# Altium schematic sheet [05] - Probe Line IO Expanders.SchDoc("[05] - Probe Line IO Expanders")
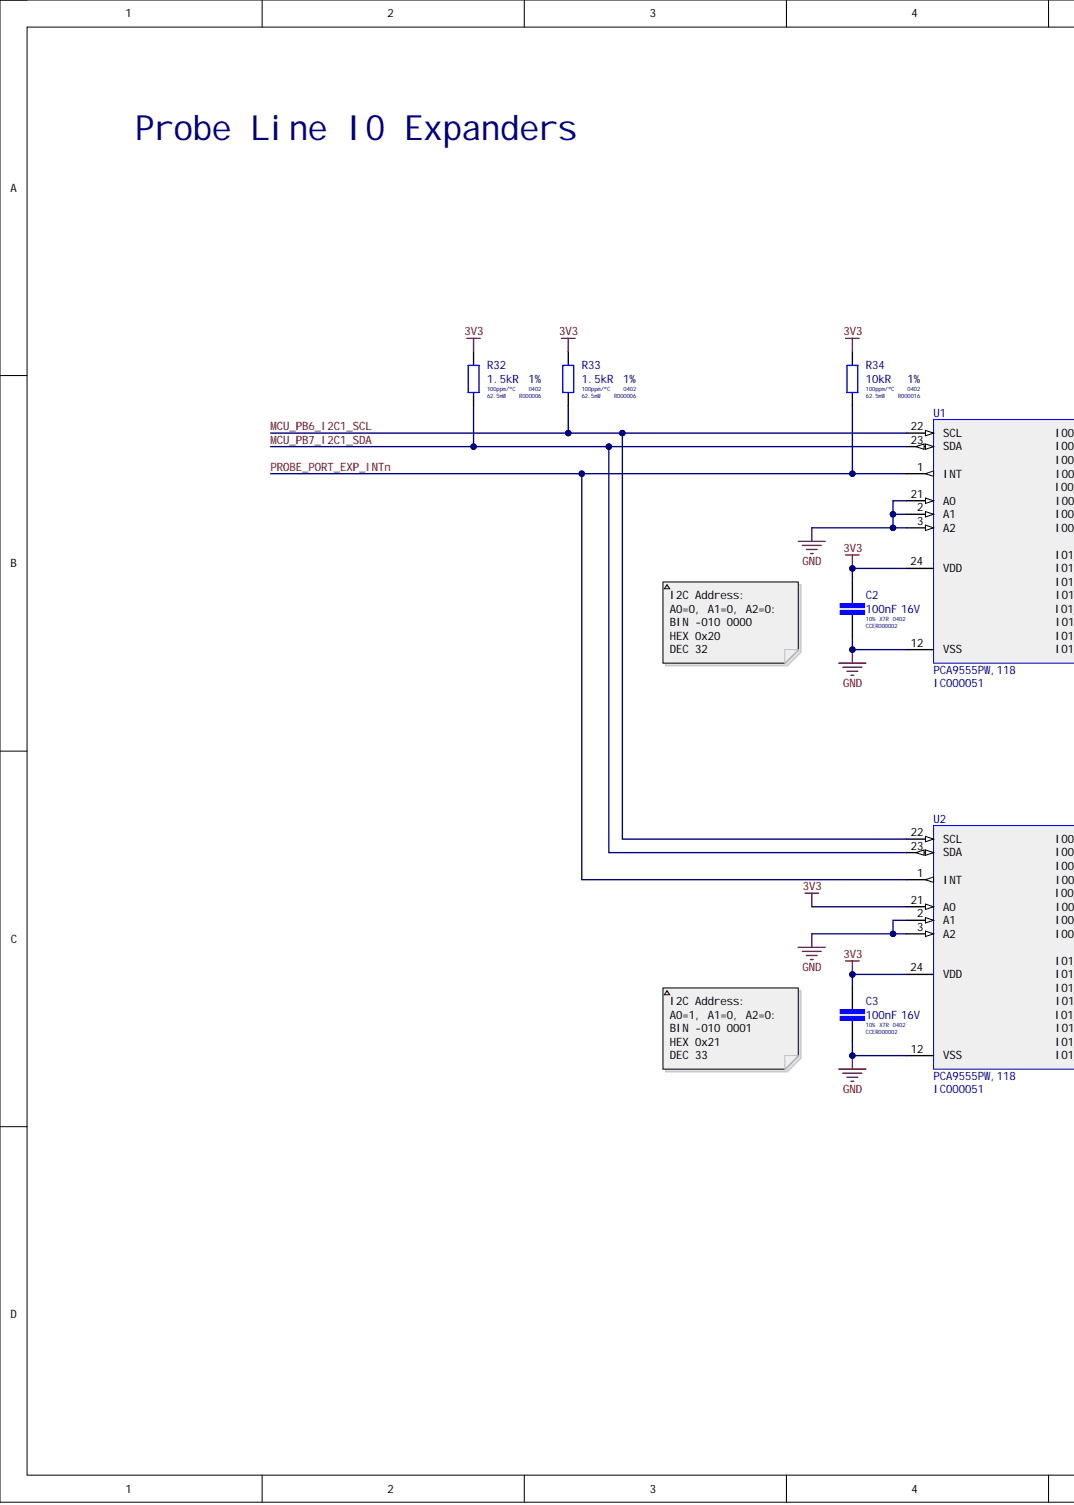
[diagram p0: [05] - Probe Line IO Expanders.SchDoc, left]
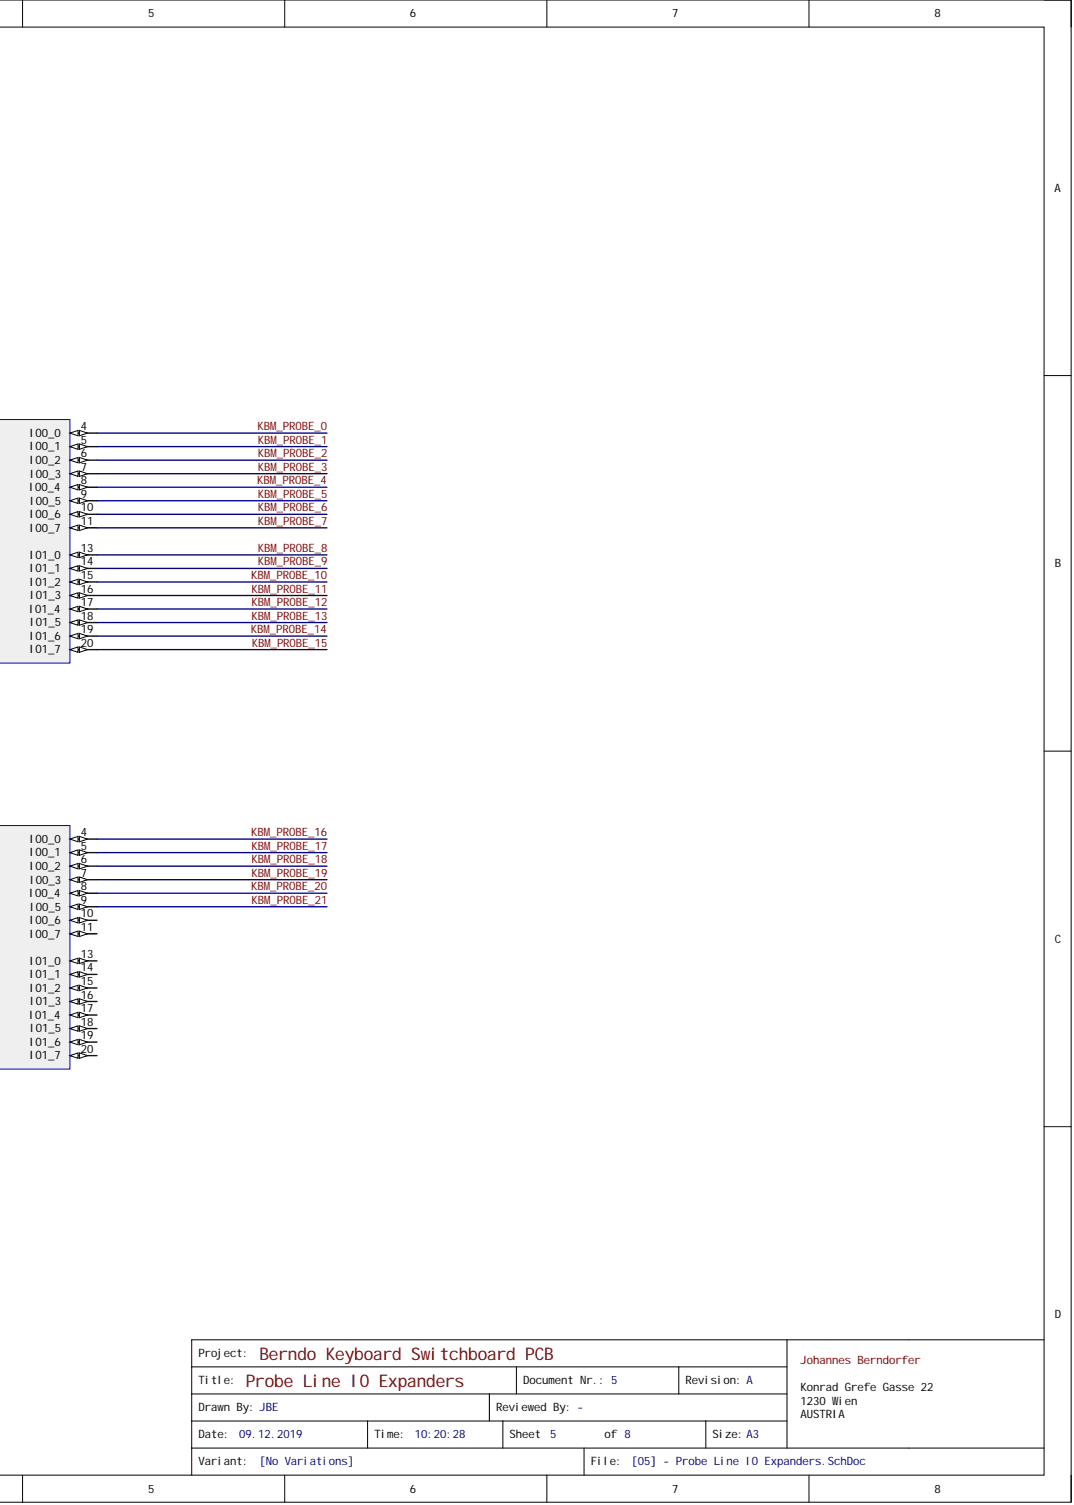
[diagram p1: [05] - Probe Line IO Expanders.SchDoc, right]

## Parts
C2  100nF 16V  Capacitance: 100nF  Dielectric: X7R  Internal Part Number: CCER000002  Tolerance: 10%  [CAP-SMD-0402-0.55MM-IPC-MED]
C3  100nF 16V  Capacitance: 100nF  Dielectric: X7R  Internal Part Number: CCER000002  Tolerance: 10%  [CAP-SMD-0402-0.55MM-IPC-MED]
R32  1.5kR 1%  Max Power Dissipation: 62.5mW  Resistance: 1.5kR  Temperature Coefficient: 100ppm/°C  [RES-SMD-0402-0.4MM-IPC-MED]
R33  1.5kR 1%  Case Code (Imperial): 0402  Internal Part Number: R000006  Max Power Dissipation: 62.5mW  Resistance: 1.5kR  Temperature Coefficient: 100ppm/°C  Tolerance: 1%  [RES-SMD-0402-0.4MM-IPC-MED]
R34  Power Rating: 62.5mW  Resistance: 10kR  Temperature Coefficient: 100ppm/°C  [RES-SMD-0402-0.4MM-IPC-MED]  (hidden comment: 10kR 1%)
U1  PCA9555PW,118  Internal Part Number: IC000051  [TSSOP-24-H1.1MM-P0.65MM-NXP]
U2  PCA9555PW,118  Internal Part Number: IC000051  [TSSOP-24-H1.1MM-P0.65MM-NXP]

## Nets
3V3: C2.1 C3.1 R32.1 R33.1 R34.1 U1.24(VDD) U2.21(A0) U2.24(VDD)
GND: C2.2 C3.2 U1.2(A1) U1.3(A2) U1.12(VSS) U1.21(A0) U2.2(A1) U2.3(A2) U2.12(VSS)
KBM_PROBE_0: U1.4(IO0_0)
KBM_PROBE_1: U1.5(IO0_1)
KBM_PROBE_2: U1.6(IO0_2)
KBM_PROBE_3: U1.7(IO0_3)
KBM_PROBE_4: U1.8(IO0_4)
KBM_PROBE_5: U1.9(IO0_5)
KBM_PROBE_6: U1.10(IO0_6)
KBM_PROBE_7: U1.11(IO0_7)
KBM_PROBE_8: U1.13(IO1_0)
KBM_PROBE_9: U1.14(IO1_1)
KBM_PROBE_10: U1.15(IO1_2)
KBM_PROBE_11: U1.16(IO1_3)
KBM_PROBE_12: U1.17(IO1_4)
KBM_PROBE_13: U1.18(IO1_5)
KBM_PROBE_14: U1.19(IO1_6)
KBM_PROBE_15: U1.20(IO1_7)
KBM_PROBE_16: U2.4(IO0_0)
KBM_PROBE_17: U2.5(IO0_1)
KBM_PROBE_18: U2.6(IO0_2)
KBM_PROBE_19: U2.7(IO0_3)
KBM_PROBE_20: U2.8(IO0_4)
KBM_PROBE_21: U2.9(IO0_5)
MCU_PB6_I2C1_SCL: R33.2 U1.22(SCL) U2.22(SCL)
MCU_PB7_I2C1_SDA: R32.2 U1.23(SDA) U2.23(SDA)
PROBE_PORT_EXP_INTn: R34.2 U1.1(INT) U2.1(INT)

Not connected: U2 10(IO0_6) 11(IO0_7) 13(IO1_0) 14(IO1_1) 15(IO1_2) 16(IO1_3) 17(IO1_4) 18(IO1_5) 19(IO1_6) 20(IO1_7)

## Library data of the parts
C2 C3 = CL05B104KO5NNNC: CAP CER 100N 16V X7R 10% SMD 0402 125C SAMSUNG; Material: Ceramic; Case Code (Imperial): 0402; Case Code (Metric): 1005; Case/Package: 0402; Component Kind: Standard; ComponentLink1Description: https://datasheet.ciiva.com/26920/getdatasheetpartid-359683-26920169.pdf?src-supplier=Verical; ComponentLink1URL: https://datasheet.ciiva.com/26920/getdatasheetpartid-359683-26920169.pdf?src-supplier=Verical; ComponentLink2Description: https://datasheet.ciiva.com/29198/458718-da-01-en-vs-kondensator-0402-29198423.pdf?src-supplier=Conrad; ComponentLink2URL: https://datasheet.ciiva.com/29198/458718-da-01-en-vs-kondensator-0402-29198423.pdf?src-supplier=Conrad; ComponentLink3Description: https://datasheet.ciiva.com/16208/cl05b104kq5nnnc-16208343.pdf?src-supplier=Future+Electronics; ComponentLink3URL: https://datasheet.ciiva.com/16208/cl05b104kq5nnnc-16208343.pdf?src-supplier=Future+Electronics; ComponentLink4Description: https://datasheet.ciiva.com/26309/mlcc-catalogdownload2013-eng-26309003.pdf?src-supplier=Distrelec; ComponentLink4URL: https://datasheet.ciiva.com/26309/mlcc-catalogdownload2013-eng-26309003.pdf?src-supplier=Distrelec; Contact Plating: Tin; Depth: 0.5mm; Height: 0.5mm; Lead Free: Lead Free; Length: 1mm; Library Name: Altium_Lib_Standard.IntLib; Manufacturer 1: Samsung; Manufacturer Part Number 1: CL05B104KO5NNNC; Max Operating Temperature: 125°C; Min Operating Temperature: -55°C; Mount: Surface Mount; Package Quantity: 1; Packaging: Tape and Reel; Pricing 1: 1=0,10000, 10=0,02400, 100=0,01070, 500=0,00766, 1000=0,00602, 2500=0,00548, 5000=0,00504 (USD); Radiation Hardening: No; RoHS: Yes; Stock 1: 53930414; Supplier 1: Digi-Key; Supplier Part Number 1: 1276-1001-6-ND; Thickness: 0.022inch; Voltage: 16V; Voltage Rating: 16V; Voltage Rating (DC): 16V; Width: 0.02inch
R32 = RC0402FR-071K5L: RES 1K5 1% 1/16W SMD 0402 YAEGO; Internal Part Number: R000006; Manufacturer: Yageo; Voltage Rating: 50V; Case Code (Imperial): 0402; Case Code (Metric): 1005; Case/Package: 0402; Component Kind: Standard; ComponentLink1Description: https://datasheet.ciiva.com/11808/0900766b80c66297-11808238.pdf?src-supplier=RSComponents; ComponentLink1URL: https://datasheet.ciiva.com/11808/0900766b80c66297-11808238.pdf?src-supplier=RSComponents; ComponentLink2Description: https://datasheet.ciiva.com/2453/pyu-r-int-thick-7-2453440.pdf?src-supplier=Digi-Key; ComponentLink2URL: https://datasheet.ciiva.com/2453/pyu-r-int-thick-7-2453440.pdf?src-supplier=Digi-Key; ComponentLink3Description: https://datasheet.ciiva.com/2453/pyu-r-int-thick-7-2453441.pdf?src-supplier=Digi-Key; ComponentLink3URL: https://datasheet.ciiva.com/2453/pyu-r-int-thick-7-2453441.pdf?src-supplier=Digi-Key; ComponentLink4Description: https://datasheet.ciiva.com/46394/1018594-46394950.pdf?src-supplier=Element14; ComponentLink4URL: https://datasheet.ciiva.com/46394/1018594-46394950.pdf?src-supplier=Element14; ComponentLink5Description: https://datasheet.ciiva.com/7849/1018594-7849864.pdf?src-supplier=Element14; ComponentLink5URL: https://datasheet.ciiva.com/7849/1018594-7849864.pdf?src-supplier=Element14; ComponentLink6Description: https://datasheet.ciiva.com/15189/getdatasheetpartid-323992-15189370.pdf?src-supplier=Verical; ComponentLink6URL: https://datasheet.ciiva.com/15189/getdatasheetpartid-323992-15189370.pdf?src-supplier=Verical; ComponentLink7Description: https://datasheet.ciiva.com/7849/1018594-7849863.pdf?src-supplier=Element14; ComponentLink7URL: https://datasheet.ciiva.com/7849/1018594-7849863.pdf?src-supplier=Element14; ComponentLink8Description: https://datasheet.ciiva.com/12558/pyu-rc0402-51-rohs-l-6-r-8721-12558542.pdf?src-supplier=Mouser; ComponentLink8URL: https://datasheet.ciiva.com/12558/pyu-rc0402-51-rohs-l-6-r-8721-12558542.pdf?src-supplier=Mouser; ComponentLink9Description: https://datasheet.ciiva.com/2453/pyu-r-int-thick-7-2453439.pdf?src-supplier=Digi-Key; ComponentLink9URL: https://datasheet.ciiva.com/2453/pyu-r-int-thick-7-2453439.pdf?src-supplier=Digi-Key; Composition: Thick Film; Depth: 0.5mm; Features: Moisture Resistant; Height: 0.016inch; Lead Free: Lead Free; Length: 1mm; Library Name: Altium_Lib_Standard.IntLib; Manufacturer Part Number: RC0402FR-071K5L; Max Operating Temperature: 155°C; Military Standard: Not; Min Operating Temperature: -55°C; Number of Terminations: 2; Package Quantity: 1; Packaging: Tape and Reel; Power Rating: 62.5mW; Pricing 1: 1 = 0,10000, 10 = 0,01600, 100 = 0,00640, 1000 = 0,00286, 2500 = 0,00248, 5000 = 0,00205 (USD); REACH SVHC: No SVHC; RoHS: Yes; Stock 1: 9091318; Supplier 1: Digi-Key; Supplier Part Number 1: 311-1.50KLRCT-ND; Termination: SMD/SMT; Tolerance: 1%; Width: 0.02inch
R33 = RC0402FR-071K5L: RES 1K5 1% 1/16W SMD 0402 YAEGO; Manufacturer: Yageo; Voltage Rating: 50V; Case Code (Metric): 1005; Case/Package: 0402; Component Kind: Standard; ComponentLink1Description: https://datasheet.ciiva.com/11808/0900766b80c66297-11808238.pdf?src-supplier=RSComponents; ComponentLink1URL: https://datasheet.ciiva.com/11808/0900766b80c66297-11808238.pdf?src-supplier=RSComponents; ComponentLink2Description: https://datasheet.ciiva.com/2453/pyu-r-int-thick-7-2453440.pdf?src-supplier=Digi-Key; ComponentLink2URL: https://datasheet.ciiva.com/2453/pyu-r-int-thick-7-2453440.pdf?src-supplier=Digi-Key; ComponentLink3Description: https://datasheet.ciiva.com/2453/pyu-r-int-thick-7-2453441.pdf?src-supplier=Digi-Key; ComponentLink3URL: https://datasheet.ciiva.com/2453/pyu-r-int-thick-7-2453441.pdf?src-supplier=Digi-Key; ComponentLink4Description: https://datasheet.ciiva.com/46394/1018594-46394950.pdf?src-supplier=Element14; ComponentLink4URL: https://datasheet.ciiva.com/46394/1018594-46394950.pdf?src-supplier=Element14; ComponentLink5Description: https://datasheet.ciiva.com/7849/1018594-7849864.pdf?src-supplier=Element14; ComponentLink5URL: https://datasheet.ciiva.com/7849/1018594-7849864.pdf?src-supplier=Element14; ComponentLink6Description: https://datasheet.ciiva.com/15189/getdatasheetpartid-323992-15189370.pdf?src-supplier=Verical; ComponentLink6URL: https://datasheet.ciiva.com/15189/getdatasheetpartid-323992-15189370.pdf?src-supplier=Verical; ComponentLink7Description: https://datasheet.ciiva.com/7849/1018594-7849863.pdf?src-supplier=Element14; ComponentLink7URL: https://datasheet.ciiva.com/7849/1018594-7849863.pdf?src-supplier=Element14; ComponentLink8Description: https://datasheet.ciiva.com/12558/pyu-rc0402-51-rohs-l-6-r-8721-12558542.pdf?src-supplier=Mouser; ComponentLink8URL: https://datasheet.ciiva.com/12558/pyu-rc0402-51-rohs-l-6-r-8721-12558542.pdf?src-supplier=Mouser; ComponentLink9Description: https://datasheet.ciiva.com/2453/pyu-r-int-thick-7-2453439.pdf?src-supplier=Digi-Key; ComponentLink9URL: https://datasheet.ciiva.com/2453/pyu-r-int-thick-7-2453439.pdf?src-supplier=Digi-Key; Composition: Thick Film; Depth: 0.5mm; Features: Moisture Resistant; Height: 0.016inch; Lead Free: Lead Free; Length: 1mm; Library Name: Altium_Lib_Standard.IntLib; Manufacturer Part Number: RC0402FR-071K5L; Max Operating Temperature: 155°C; Military Standard: Not; Min Operating Temperature: -55°C; Number of Terminations: 2; Package Quantity: 1; Packaging: Tape and Reel; Power Rating: 62.5mW; Pricing 1: 1 = 0,10000, 10 = 0,01600, 100 = 0,00640, 1000 = 0,00286, 2500 = 0,00248, 5000 = 0,00205 (USD); REACH SVHC: No SVHC; RoHS: Yes; Stock 1: 9091318; Supplier 1: Digi-Key; Supplier Part Number 1: 311-1.50KLRCT-ND; Termination: SMD/SMT; Width: 0.02inch
R34 = RC0402FR-0710KL: RES 10K 1% 1/16W SMD 0402 YAEGO; Internal Part Number: R000016; Manufacturer: Yageo; Voltage Rating: 50V; Case Code (Imperial): 0402; Case Code (Metric): 1005; Case/Package: 0402; Component Kind: Standard; ComponentLink10Description: https://datasheet.ciiva.com/24070/pyu-rc0402-51-rohs-l-6-r-8721-24070077.pdf?src-supplier=Mouser; ComponentLink10URL: https://datasheet.ciiva.com/24070/pyu-rc0402-51-rohs-l-6-r-8721-24070077.pdf?src-supplier=Mouser; ComponentLink11Description: https://datasheet.ciiva.com/7849/1018594-7849966.pdf?src-supplier=Element14; ComponentLink11URL: https://datasheet.ciiva.com/7849/1018594-7849966.pdf?src-supplier=Element14; ComponentLink12Description: https://datasheet.ciiva.com/7849/1018594-7849967.pdf?src-supplier=Element14; ComponentLink12URL: https://datasheet.ciiva.com/7849/1018594-7849967.pdf?src-supplier=Element14; ComponentLink13Description: https://datasheet.ciiva.com/17070/pyu-rc0402-51-rohs-l-6-r-17070335.pdf?src-supplier=Future+Electronics; ComponentLink13URL: https://datasheet.ciiva.com/17070/pyu-rc0402-51-rohs-l-6-r-17070335.pdf?src-supplier=Future+Electronics; ComponentLink1Description: https://datasheet.ciiva.com/7660/38568-7660787.pdf?src-supplier=Element14; ComponentLink1URL: https://datasheet.ciiva.com/7660/38568-7660787.pdf?src-supplier=Element14; ComponentLink2Description: https://datasheet.ciiva.com/7849/1784430-7849813.pdf?src-supplier=Element14; ComponentLink2URL: https://datasheet.ciiva.com/7849/1784430-7849813.pdf?src-supplier=Element14; ComponentLink3Description: https://datasheet.ciiva.com/7849/1686128-7849814.pdf?src-supplier=Element14; ComponentLink3URL: https://datasheet.ciiva.com/7849/1686128-7849814.pdf?src-supplier=Element14; ComponentLink4Description: https://datasheet.ciiva.com/7849/1784430-7849815.pdf?src-supplier=Element14; ComponentLink4URL: https://datasheet.ciiva.com/7849/1784430-7849815.pdf?src-supplier=Element14; ComponentLink5Description: https://datasheet.ciiva.com/22747/1018594-22747512.pdf?src-supplier=Element14; ComponentLink5URL: https://datasheet.ciiva.com/22747/1018594-22747512.pdf?src-supplier=Element14; ComponentLink6Description: https://datasheet.ciiva.com/12667/pyu-rc0402-51-rohs-l-6-r-12667067.pdf?src-supplier=Mouser; ComponentLink6URL: https://datasheet.ciiva.com/12667/pyu-rc0402-51-rohs-l-6-r-12667067.pdf?src-supplier=Mouser; ComponentLink7Description: https://datasheet.ciiva.com/7983/1018594-7983486.pdf?src-supplier=Element14; ComponentLink7URL: https://datasheet.ciiva.com/7983/1018594-7983486.pdf?src-supplier=Element14; ComponentLink8Description: https://datasheet.ciiva.com/11819/0900766b80c66297-11819487.pdf?src-supplier=RSComponents; ComponentLink8URL: https://datasheet.ciiva.com/11819/0900766b80c66297-11819487.pdf?src-supplier=RSComponents; ComponentLink9Description: https://datasheet.ciiva.com/15189/getdatasheetpartid-329253-15189431.pdf?src-supplier=Verical; ComponentLink9URL: https://datasheet.ciiva.com/15189/getdatasheetpartid-329253-15189431.pdf?src-supplier=Verical; Composition: Thick Film; Depth: 0.5mm; Height: 0.32mm; Lead Free: Lead Free; Lead Pitch: 0.2mm; Length: 1mm; Library Name: Altium_Lib_Standard.IntLib; Manufacturer Part Number: RC0402FR-0710KL; Max Operating Temperature: 155°C; Military Standard: Not; Min Operating Temperature: -55°C; Number of Pins: 2; Number of Terminations: 2; Package Quantity: 10000; Packaging: Tape and Reel; Pricing 1: 1 = 0,10000, 10 = 0,01600, 100 = 0,00670, 1000 = 0,00301, 2500 = 0,00261, 5000 = 0,00215 (USD); REACH SVHC: No SVHC; RoHS: Yes; Stock 1: 86154015; Supplier 1: Digi-Key; Supplier Part Number 1: 311-10.0KLRCT-ND; Termination: SMD/SMT; Tolerance: 1%; Voltage Rating (DC): 50V; Width: 0.5mm; Working Voltage: 50V
U1 U2 = PCA9555PW,118: IC I/O EXPANDER I2C 16B 24TSSOP; Manufacturer: NXP Semiconductors; Frequency: 400kHz; Interface: I2C,SMBus,Serial; Max Power Dissipation: 200mW; Max Supply Voltage: 5.5V; Min Supply Voltage: 2.3V; Nominal Supply Current: 160mA; Number of I/Os: 16; Operating Supply Current: 135uA; Output Current: 50mA; Output Voltage: 4.1V; Case/Package: TSSOP; Component Kind: Standard; ComponentLink1Description: https://datasheet.ciiva.com/15134/getdatasheetpartid-148982-15134333.pdf?src-supplier=Verical; ComponentLink1URL: https://datasheet.ciiva.com/15134/getdatasheetpartid-148982-15134333.pdf?src-supplier=Verical; ComponentLink2Description: https://datasheet.ciiva.com/1780/pca9555-1780068.pdf?src-supplier=Digi-Key; ComponentLink2URL: https://datasheet.ciiva.com/1780/pca9555-1780068.pdf?src-supplier=Digi-Key; ComponentLink3Description: https://datasheet.ciiva.com/1504/1090559-1504901.pdf?src-supplier=Farnell; ComponentLink3URL: https://datasheet.ciiva.com/1504/1090559-1504901.pdf?src-supplier=Farnell; ComponentLink4Description: https://datasheet.ciiva.com/1780/pca9555-1780069.pdf?src-supplier=Digi-Key; ComponentLink4URL: https://datasheet.ciiva.com/1780/pca9555-1780069.pdf?src-supplier=Digi-Key; ComponentLink5Description: https://datasheet.ciiva.com/1780/pca9555-1780070.pdf?src-supplier=Digi-Key; ComponentLink5URL: https://datasheet.ciiva.com/1780/pca9555-1780070.pdf?src-supplier=Digi-Key; ComponentLink6Description: https://datasheet.ciiva.com/1495/1090559-1495945.pdf?src-supplier=Element14; ComponentLink6URL: https://datasheet.ciiva.com/1495/1090559-1495945.pdf?src-supplier=Element14; ComponentLink7Description: https://datasheet.ciiva.com/8122/pca9555-8122281.pdf?src-supplier=Avnet; ComponentLink7URL: https://datasheet.ciiva.com/8122/pca9555-8122281.pdf?src-supplier=Avnet; ComponentLink8Description: https://datasheet.ciiva.com/46381/1090559-46381881.pdf?src-supplier=Element14; ComponentLink8URL: https://datasheet.ciiva.com/46381/1090559-46381881.pdf?src-supplier=Element14; ComponentLink9Description: https://datasheet.ciiva.com/4826/pca9555-4826810.pdf?src-supplier=Mouser; ComponentLink9URL: https://datasheet.ciiva.com/4826/pca9555-4826810.pdf?src-supplier=Mouser; Lead Free: Lead Free; Library Name: Altium_Lib_Standard.IntLib; Manufacturer Part Number: PCA9555PW,118; Max Frequency: 400kHz; Max Operating Temperature: 85°C; Min Operating Temperature: -40°C; Mount: Surface Mount; Number of Pins: 24; Package Quantity: 1; Packaging: Tape and Reel; Pricing 1: 1 = 1,70000, 10 = 1,52900, 100 = 1,22860, 500 = 1,00940, 1000 = 0,83636 (USD); Radiation Hardening: No; REACH SVHC: No SVHC; RoHS: Yes; Stock 1: 10944; Supplier 1: Digi-Key; Supplier Part Number 1: 568-1058-1-ND
